# Altium schematic sheet DEVICE.SchDoc(DEVICE)
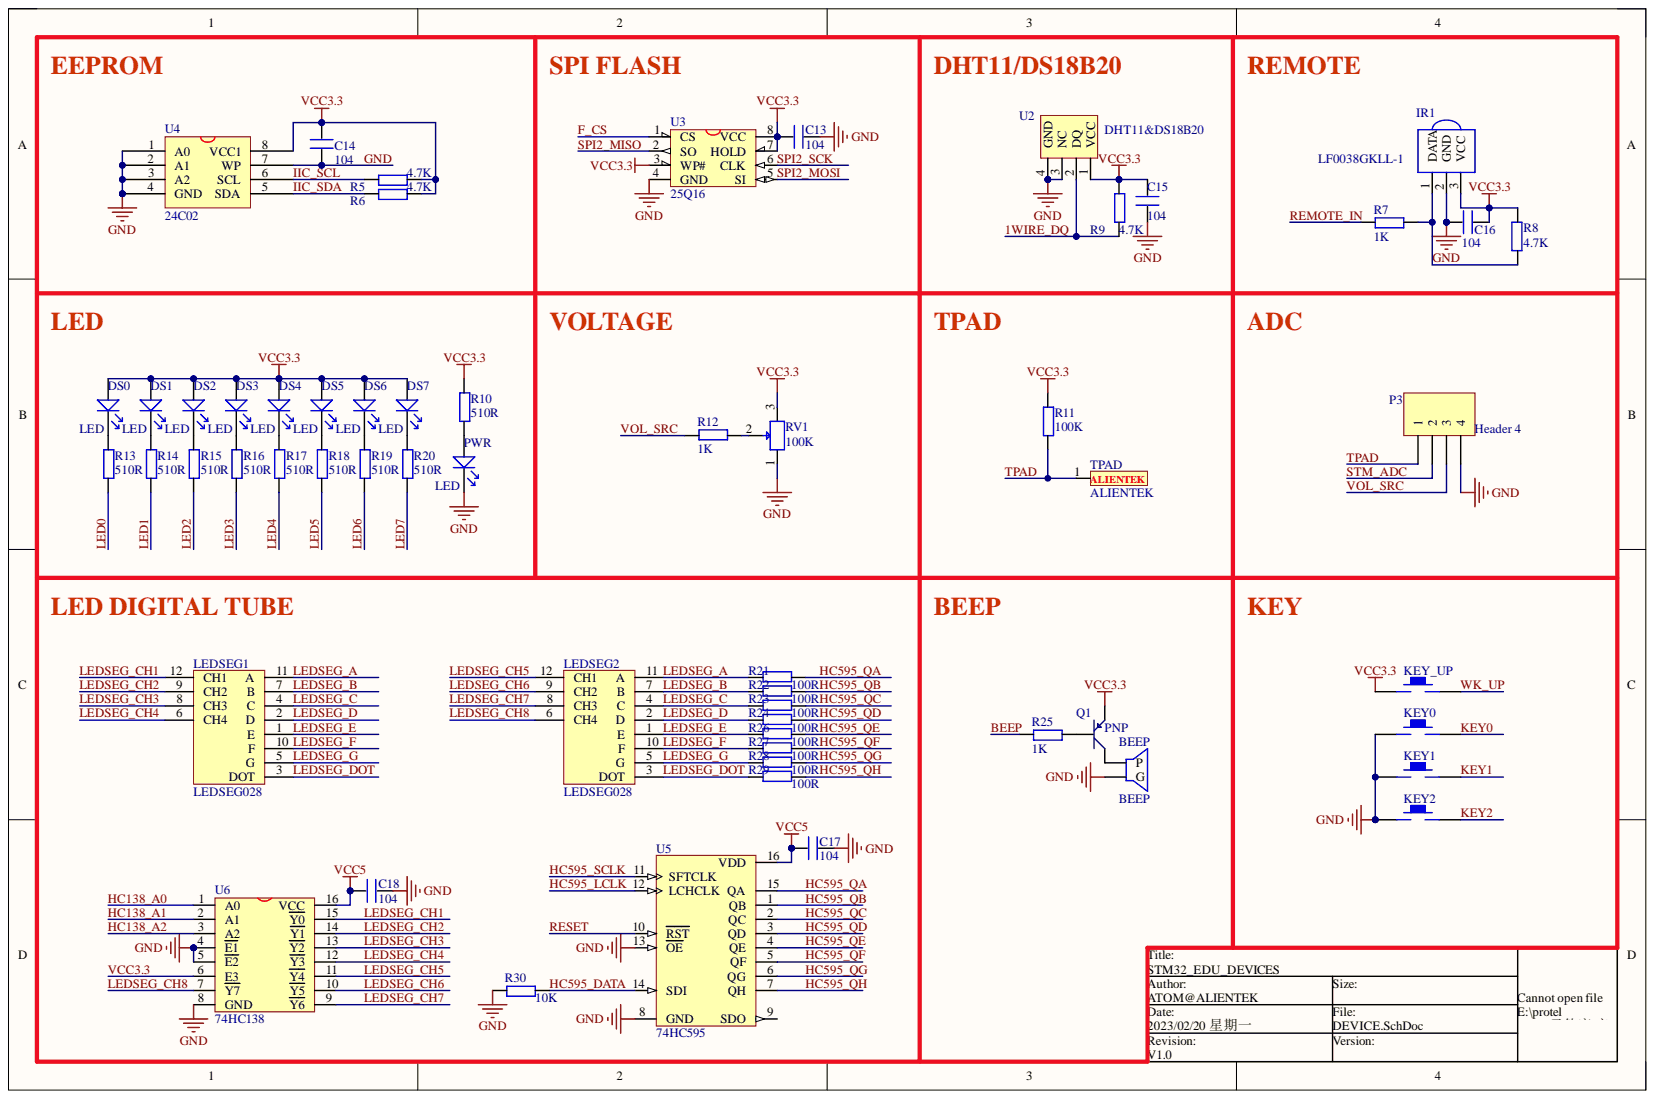
[diagram p0: DEVICE.SchDoc]

## Parts
BEEP  BEEP  [BELL-5]
C13  104  [0603P]
C14  104  [0603P]
C15  104  [0603P]
C16  104  [0603P]
C17  104  [0603P]
C18  104  [0603P]
DS0  LED  [SMDLED-0805]
DS1  LED  [SMDLED-0805]
DS2  LED  [SMDLED-0805]
DS3  LED  [SMDLED-0805]
DS4  LED  [SMDLED-0805]
DS5  LED  [SMDLED-0805]
DS6  LED  [SMDLED-0805]
DS7  LED  [SMDLED-0805]
IR1  LF0038GKLL-1  [LF0038GKLL-1]
KEY2  KEY_UP  [KEY_SOP324225]
KEY3  KEY0  [KEY_SOP324225]
KEY4  KEY1  [KEY_SOP324225]
KEY5  KEY2  [KEY_SOP324225]
LEDSEG1  LEDSEG028  [LEDDISPLAY-0.28]
LEDSEG2  LEDSEG028  [LEDDISPLAY-0.28]
P3  Header 4  [HDR1X4]
PWR  LED  [SMDLED-0805]
Q1  PNP  [SOT-23R]
R5  4.7K  [0603P]
R6  4.7K  [0603P]
R7  1K  [0603P]
R8  4.7K  [0603P]
R9  4.7K  [0603P]
R10  510R  [0603P]
R11  100K  [0603P]
R12  1K  [0603P]
R13  510R  [0603P]
R14  510R  [0603P]
R15  510R  [0603P]
R16  510R  [0603P]
R17  510R  [0603P]
R18  510R  [0603P]
R19  510R  [0603P]
R20  510R  [0603P]
R21  100R  [0603P]
R22  100R  [0603P]
R23  100R  [0603P]
R24  100R  [0603P]
R25  1K  [0603P]
R26  100R  [0603P]
R27  100R  [0603P]
R28  100R  [0603P]
R29  100R  [0603P]
R30  10K  [0603P]
RV1  100K  [RV]
TPAD  ALIENTEK  [LOGO_TPAD]
U2  DHT11&DS18B20  [DHT11]
U3  25Q16  [SOIC-8]
U4  24C02  [SOP-8]
U5  74HC595  [SOP16M]
U6  74HC138  [SOP16M]

## Nets
VCC5: C17.2 C18.2 U5.16(VDD) U6.16(VCC)
1WIRE_DQ: R9.1 U2.2(DQ)
BEEP: R25.1
F_CS: U3.1(CS)
GND: BEEP.2 C13.2 C14.1 C15.2 C16.2 C17.1 C18.1 IR1.2 KEY3.1 KEY4.1 KEY5.1 P3.4 PWR.2 R30.1 RV1.1 U2.3(NC) U2.4(GND) U3.4(GND) U4.1(A0) U4.2(A1) U4.3(A2) U4.4(GND) U4.7(WP) U5.8(GND) U5.13(OE) U6.4(E1) U6.5(E2) U6.8(GND)
HC138_A0: U6.1(A0)
HC138_A1: U6.2(A1)
HC138_A2: U6.3(A2)
HC595_DATA: R30.2 U5.14(SDI)
HC595_LCLK: U5.12(LCHCLK)
HC595_QA: R21.1 U5.15(QA)
HC595_QB: R22.1 U5.1(QB)
HC595_QC: R23.1 U5.2(QC)
HC595_QD: R24.1 U5.3(QD)
HC595_QE: R26.1 U5.4(QE)
HC595_QF: R27.1 U5.5(QF)
HC595_QG: R28.1 U5.6(QG)
HC595_QH: R29.1 U5.7(QH)
HC595_SCLK: U5.11(SFTCLK)
IIC_SCL: R5.1 U4.6(SCL)
IIC_SDA: R6.1 U4.5(SDA)
KEY0: KEY3.2
KEY1: KEY4.2
KEY2: KEY5.2
LED0: R13.1
LED1: R14.1
LED2: R15.1
LED3: R16.1
LED4: R17.1
LED5: R18.1
LED6: R19.1
LED7: R20.1
LEDSEG_A: LEDSEG1.11(A) LEDSEG2.11(A) R21.2
LEDSEG_B: LEDSEG1.7(B) LEDSEG2.7(B) R22.2
LEDSEG_C: LEDSEG1.4(C) LEDSEG2.4(C) R23.2
LEDSEG_CH1: LEDSEG1.12(CH1) U6.15(Y0)
LEDSEG_CH2: LEDSEG1.9(CH2) U6.14(Y1)
LEDSEG_CH3: LEDSEG1.8(CH3) U6.13(Y2)
LEDSEG_CH4: LEDSEG1.6(CH4) U6.12(Y3)
LEDSEG_CH5: LEDSEG2.12(CH1) U6.11(Y4)
LEDSEG_CH6: LEDSEG2.9(CH2) U6.10(Y5)
LEDSEG_CH7: LEDSEG2.8(CH3) U6.9(Y6)
LEDSEG_CH8: LEDSEG2.6(CH4) U6.7(Y7)
LEDSEG_D: LEDSEG1.2(D) LEDSEG2.2(D) R24.2
LEDSEG_DOT: LEDSEG1.3(DOT) LEDSEG2.3(DOT) R29.2
LEDSEG_E: LEDSEG1.1(E) LEDSEG2.1(E) R26.2
LEDSEG_F: LEDSEG1.10(F) LEDSEG2.10(F) R27.2
LEDSEG_G: LEDSEG1.5(G) LEDSEG2.5(G) R28.2
REMOTE_IN: R7.1
RESET: U5.10(RST)
SPI2_MISO: U3.2(SO)
SPI2_MOSI: U3.5(SI)
SPI2_SCK: U3.6(CLK)
STM_ADC: P3.2
TPAD: P3.1 R11.1 TPAD.1
VCC3.3: C13.1 C14.2 C15.1 C16.1 DS0.1 DS1.1 DS2.1 DS3.1 DS4.1 DS5.1 DS6.1 DS7.1 IR1.3 KEY2.1 Q1.1 R5.2 R6.2 R8.1 R9.2 R10.2 R11.2 RV1.3 U2.1(VCC) U3.3(WP#) U3.7(HOLD) U3.8(VCC) U4.8(VCC1) U6.6(E3)
VOL_SRC: P3.3 R12.2
WK_UP: KEY2.2
NetBEEP_1: BEEP.1 Q1.3
NetDS0_2: DS0.2 R13.2
NetDS1_2: DS1.2 R14.2
NetDS2_2: DS2.2 R15.2
NetDS3_2: DS3.2 R16.2
NetDS4_2: DS4.2 R17.2
NetDS5_2: DS5.2 R18.2
NetDS6_2: DS6.2 R19.2
NetDS7_2: DS7.2 R20.2
NetIR1_1: IR1.1 R7.2 R8.2
NetPWR_1: PWR.1 R10.1
NetQ1_2: Q1.2 R25.2
NetR12_1: R12.1 RV1.2

Not connected: U5 9(SDO)

## Library data of the parts
C13-C18 = C: Component Kind: Standard
  C13-C16: Library Name: MYCOMLIB.IntLib
  C17 C18: Library Name: ALIENTEK_COMPONENT.IntLib
IR1 = LF0038GKLL-1: 红外接收管SMD; Component Kind: Standard; Library Name: MiniSTM32F103.IntLib
KEY2-KEY5 = KEY_M: Component Kind: Standard; Library Name: ALIENTEK_COMPONENT.IntLib
LEDSEG1 LEDSEG2 = LEDSEG028: 数码管,0.28寸,4位,共阴,30*10.2*4; Component Kind: Standard; Library Name: ALIENTEK_COMPONENT.IntLib
P3 = Header 4: Header, 4-Pin; Component Kind: Standard; LatestRevisionDate: 17-Jul-2002; LatestRevisionNote: Re-released for DXP Platform.; Library Name: Miscellaneous Connectors.IntLib; Publisher: Altium Limited
Q1 = PNP: 8550/BCW68; Component Kind: Standard; Library Name: ALIENTEK_COMPONENT.IntLib
R5-R30 = R: Component Kind: Standard
  R5-R20 R25 R30: Library Name: MYCOMLIB.IntLib
  R21-R24 R26-R29: Library Name: ALIENTEK_COMPONENT.IntLib
RV1 = RV: Component Kind: Standard; Library Name: MiniSTM32F103.IntLib
U2 = DHT11: 数字温湿度传感器; Component Kind: Standard; Library Name: ALIENTEK_COMPONENT.IntLib
U3 = W25X16: W25X16;SST25VF016;MX25L1605; Component Kind: Standard; Library Name: MYCOMLIB.IntLib
U4 = 24C256: Component Kind: Standard; Library Name: MYCOMLIB.IntLib
BEEP = BEEP: Component Kind: Standard; Library Name: ALIENTEK_COMPONENT.IntLib
DS0-DS7 PWR = LED: Component Kind: Standard; Library Name: ALIENTEK_COMPONENT.IntLib
TPAD = ALIENTEK: Component Kind: Standard; Library Name: WARSHIP_STM32_V4.4.IntLib
U5 = 74HC595: Component Kind: Standard; Library Name: ALIENTEK_COMPONENT.IntLib
U6 = 74HC138: Component Kind: Standard; Library Name: ALIENTEK_COMPONENT.IntLib
